# Altium schematic sheet Battery_Regulator.SchDoc
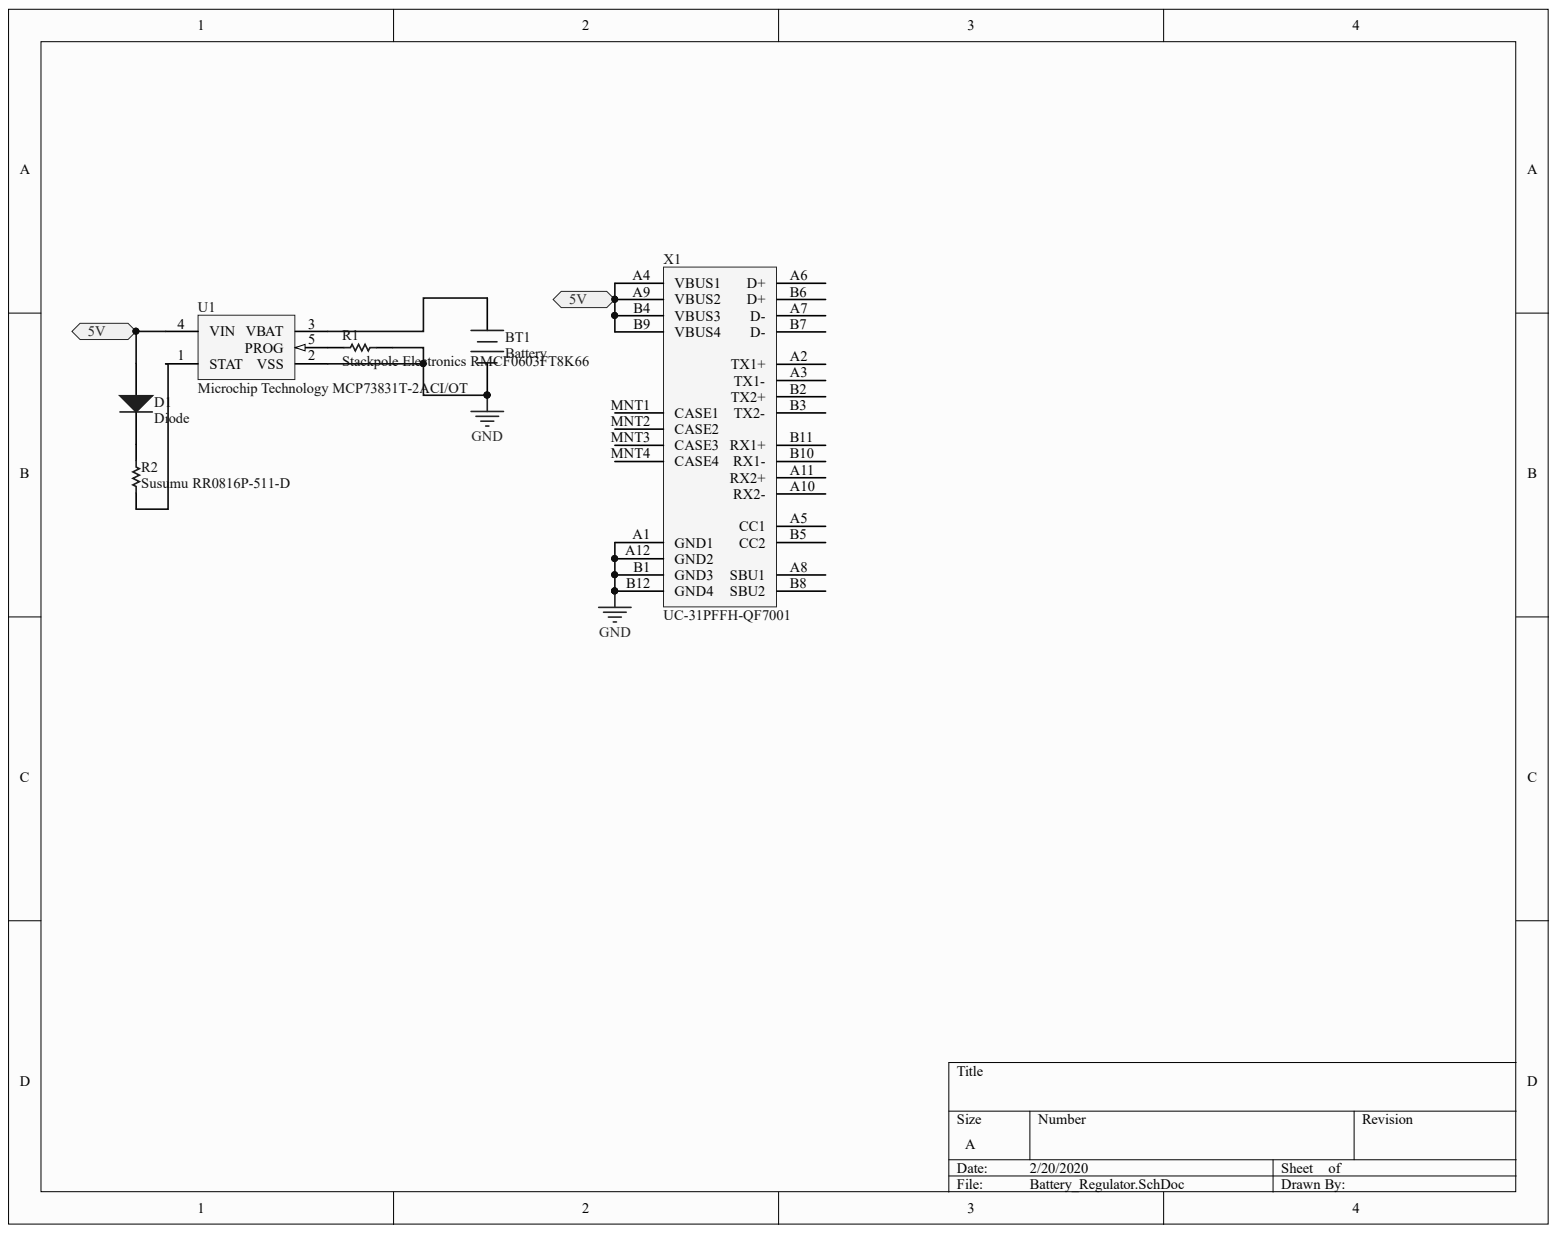
[diagram p0: Battery_Regulator.SchDoc]

## Parts
BT1  Battery  [PCB-2st0saz3albmfz68boof-1]
D1  Diode  [PCB-6c3zjm4k1kvwna5xzjsx-1]
R1  Stackpole Electronics RMCF0603FT8K66  [CAPC1708X06N]
R2  Susumu RR0816P-511-D  [RESC1608X50X30LL20T20]
U1  Microchip Technology MCP73831T-2ACI/OT  [SOT23-5_D]
X1  UC-31PFFH-QF7001  [PCB-bmwylus570qrloff17o1-1]

## Ports
5V

## Nets
GND: BT1.- R1.2 U1.2(VSS) X1.A1(GND1) X1.A12(GND2) X1.B1(GND3) X1.B12(GND4)
5V: D1.1 U1.4(VIN) X1.A4(VBUS1) X1.A9(VBUS2) X1.B4(VBUS3) X1.B9(VBUS4) ; port 5V
NetBT1_+: BT1.+ U1.3(VBAT)
NetD1_2: D1.2 R2.2
NetR1_1: R1.1 U1.5(PROG)
NetR2_1: R2.1 U1.1(STAT)

Not connected: X1 A2(TX1+) A3(TX1-) A5(CC1) A6(D+) A7(D-) A8(SBU1) A10(RX2-) A11(RX2+) B2(TX2+) B3(TX2-) B5(CC2) B6(D+) B7(D-) B8(SBU2) B10(RX1-) B11(RX1+) MNT1(CASE1) MNT2(CASE2) MNT3(CASE3) MNT4(CASE4)

## Library data of the parts
BT1 = CMP-a19d6895dad27e5a-2: Battery Retainer Coin 20MM SMD; Component Kind: Standard; Library Name: CommunityVault; Mounting Style: Surface Mount, Solder; Octopart URL: https://octopart.com/bk-883-tr-memory+protection+devices-155177; Packaging: Cut Tape (CT); Size-Diameter: 20.0 mm
D1 = CMP-8bcb47e0445b540c-1: IN-S63AT Series White 0603 120° 900 mcd 3.9 V Surface Mount Top View LED; Component Kind: Standard; Library Name: CommunityVault
R1 = CMP-2e03e62c2885f1c4-1: Res Thick Film 0603 8.66K Ohm 1% 1/10W ±100ppm/°C Molded SMD SMD Paper T/R; Lead-Free Status: Lead Free; Power Rating: 100 mW; Resistance: 8.66 k?; Case/Package: 0603; Component Kind: Standard; Library Name: FavoritesLibrary; Manufacturer Part Number: RMCF0603FT8K66; Mounting Style: Surface Mount; OctopartID: 2e03e62c2885f1c4; Octopart URL: https://octopart.com/rmcf0603ft8k66-stackpole+electronics-19211232; Packaging: Tape & Reel (TR); Resistance Tolerance: ±1%; RoHS: Compliant
R2 = CMP-1864586-2: RES SMD 510 OHM 0.5% 1/16W 0816; Lead-Free Status: Lead Free; Power Rating: 0.063 W; Resistance: 510.0 Ω; Voltage Rating (DC): 75.0 V; Case/Package: 0603; Component Kind: Standard; Library Name: CommunityVault; Manufacturer Part Number: RR0816P-511-D; Mounting Style: Surface Mount; Number of Pins: 2; OctopartID: 3927f023e603e6e0; Octopart Url: https://octopart.com/rr0816p-511-d-susumu-981570; Packaging: Cut Tape (CT); Resistance Tolerance: ±0.5%; RoHS: Compliant; Size-Height: 0.0004 m; Size-Length: 0.0016 m; Size-Width: 0.0008 m
U1 = CMP-961385-12: MCP73831 Series Single-Cell Li-Ion/Li-Polymer Battery Charge Controller SOT-23-5; Input Voltage (DC): 3.75 V (min); Lead-Free Status: Lead Free; Output Voltage: 7.30 V; Supply Voltage (DC): 6.00 V (max); Case/Package: SOT-23; Component Kind: Standard; Library Name: CommunityVault; Lifecycle Status: Active; Manufacturer Part Number: MCP73831T-2ACI/OT; Mounting Style: Surface Mount; Number of Pins: 5; OctopartID: d79a1dddcae5085d; Octopart Url: http://octopart.com/mcp73831t-2aci%2Fot-microchip-55392281; Packaging: Cut Tape (CT), Tape & Reel (TR); REACH SVHC Compliance: No SVHC; RoHS: Compliant; Seller Landing  Url: https://octopart-clicks.com/click/track?country=US&ak=8157f166&sig=05342b1&sid=459&ppid=55392281&vpid=188043932&ct=offers
X1 = CMP-223feeb0f785fdf8-2: Connector; USB SINGALWATERPROOF; JACK USB3.1 TYPE C; G2; WITH TID; Cut Tape; Gender: Female; Component Kind: Standard; Contact Material: Copper Alloy; Contact Plating: Gold; Library Name: CommunityVault; Manufacturer Part Number: UC-31PFFH-QF7001; OctopartID: 223feeb0f785fdf8; Octopart URL: https://octopart.com/uc-31pffh-qf7001-amphenol+ltw-92664028; Orientation: Right Angle; RoHS: Compliant
